# Altium schematic sheet lv_branch_24v.SchDoc(lv_branch_24v)
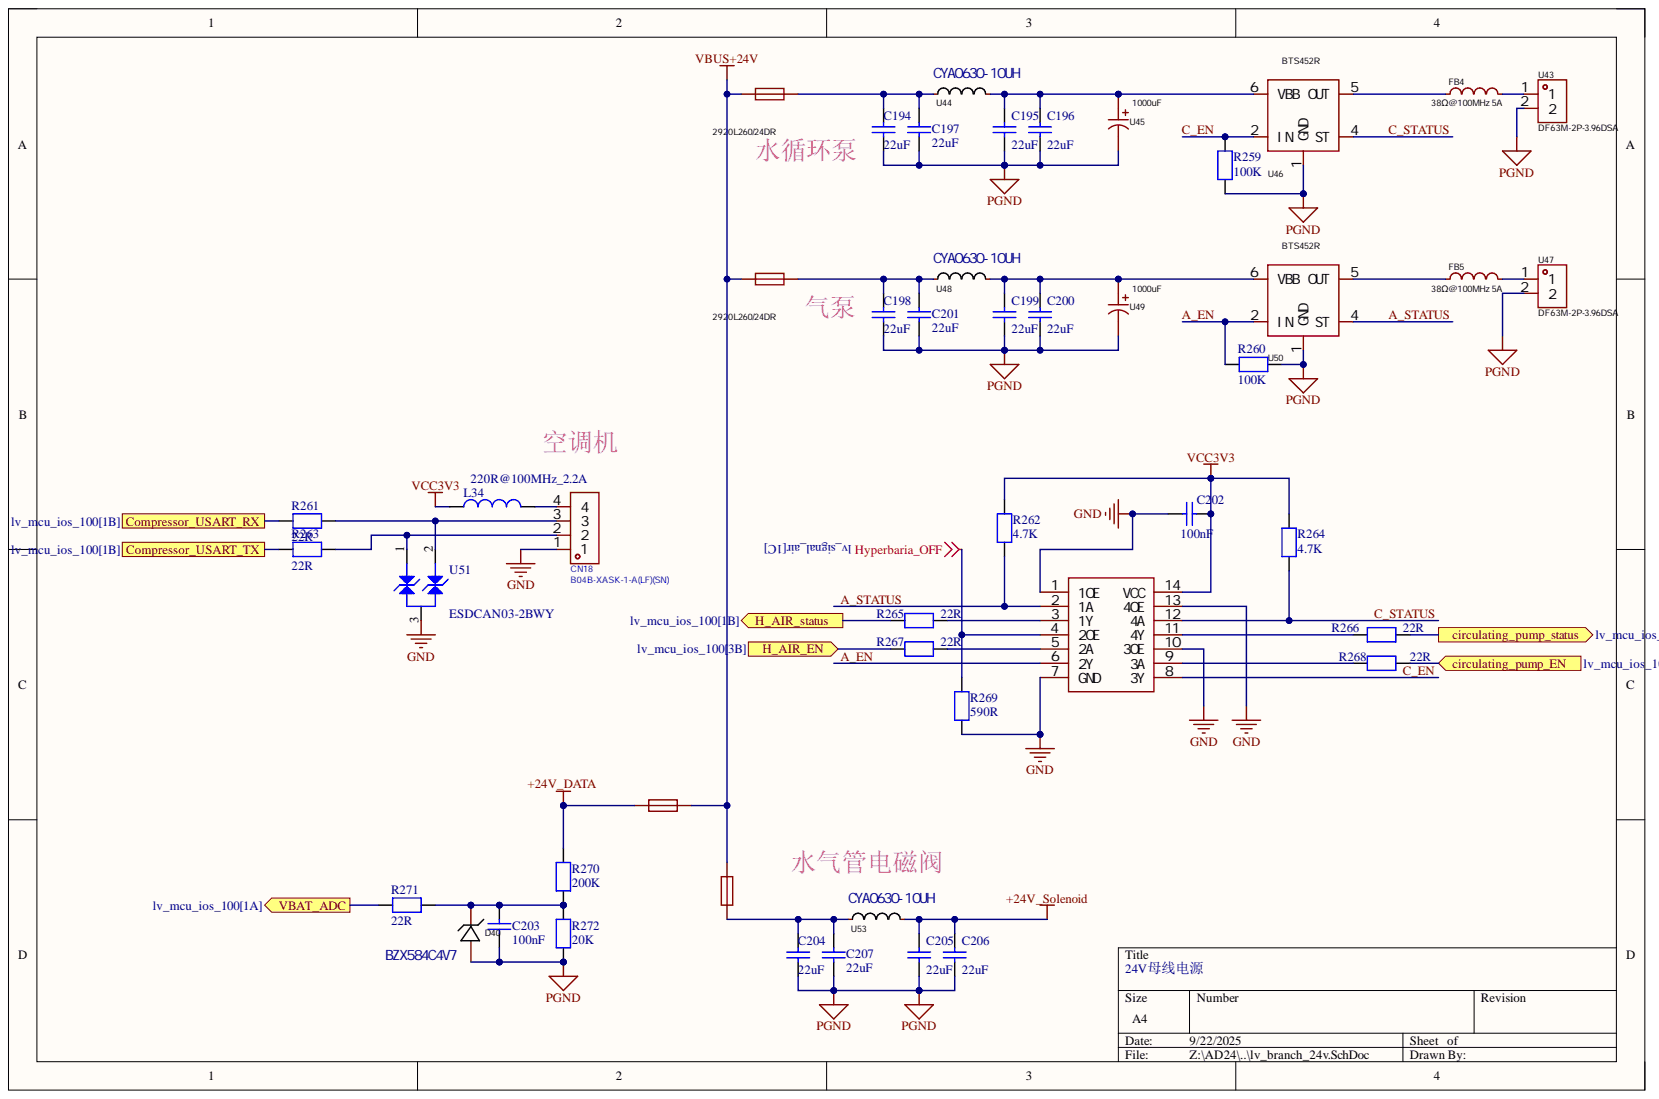
[diagram p0: lv_branch_24v.SchDoc]

## Parts
C194  22uF  [C1206]
C195  22uF  [C1206]
C196  22uF  [C1206]
C197  22uF  [C1206]
C198  22uF  [C1206]
C199  22uF  [C1206]
C200  22uF  [C1206]
C201  22uF  [C1206]
C202  100nF  [C0402]
C203  100nF  [C0402]
C204  22uF  [C1206]
C205  22uF  [C1206]
C206  22uF  [C1206]
C207  22uF  [C1206]
CN18  B04B-XASK-1-A(LF)(SN)  [CONN-TH_B04B-XASK-1-A-LF-SN]
D40  BZX584C4V7  [SOD-523F_L1.2-W0.8-LS1.7-RD]
F3  2920L260/24DR  [F2920]
F4  2920L260/24DR  [F2920]
F5  2920L260/24DR  [F2920]
F6  2920L260/24DR  [F2920]
FB4  LCSC Part Name: 38Ω@100MHz 5A  [L1206]  (hidden comment: PZ3216D380-5R0TF)
FB5  LCSC Part Name: 38Ω@100MHz 5A  [L1206]  (hidden comment: PZ3216D380-5R0TF)
L34  220R@100MHz_2.2A  [L0603]
R259  100K  [R0402]
R260  100K  [R0402]
R261  22R  [R0402]
R262  4.7K  [R0402]
R263  22R  [R0402]
R264  4.7K  [R0402]
R265  22R  [R0402]
R266  22R  [R0402]
R267  22R  [R0402]
R268  22R  [R0402]
R269  590R  [R0402]
R270  200K  [R0603]
R271  22R  [R0402]
R272  20K  [R0603]
U43  DF63M-2P-3.96DSA  [CONN-TH_2P-P3.96_DF63M-2P-3.96DSA]
U44  CYA0630-10UH  [IND-SMD_L7.2-W6.6_GPSR07X0]
U45  1000uF  [CAP-TH_BD10.0-P5.00-D0.6-FD]
U46  BTS452R  [TO-252-5_L6.5-W6.2-P1.14-LS10.0-TL]
U47  DF63M-2P-3.96DSA  [CONN-TH_2P-P3.96_DF63M-2P-3.96DSA]
U48  CYA0630-10UH  [IND-SMD_L7.2-W6.6_GPSR07X0]
U49  1000uF  [CAP-TH_BD10.0-P5.00-D0.6-FD]
U50  BTS452R  [TO-252-5_L6.5-W6.2-P1.14-LS10.0-TL]
U51  ESDCAN03-2BWY  [DIO_SOT323]
U52  74LVC125APW,118  [TSSOP-14_L5.0-W4.4-P0.65-LS6.4-BL]  (value not drawn)
U53  CYA0630-10UH  [IND-SMD_L7.2-W6.6_GPSR07X0]

## Ports
circulating_pump_EN, circulating_pump_status, Compressor_USART_RX, Compressor_USART_TX, H_AIR_EN, H_AIR_status, VBAT_ADC

## Nets
+24V_DATA: F5.2 R270.1
+24V_Solenoid: C205.2 C206.2 U53.2
GND: C202.1 CN18.1 R269.2 U51.3 U52.1(1OE) U52.7(GND) U52.10(3OE) U52.13(4OE)
Hyperbaria_OFF: R269.1 U52.4(2OE)
PGND: C194.1 C195.1 C196.1 C197.1 C198.1 C199.1 C200.1 C201.1 C203.1 C204.1 C205.1 C206.1 C207.1 D40.2 R259.1 R260.2 R272.2 U43.2 U45.2 U46.1(GND) U47.2 U49.2 U50.1(GND)
VBUS+24V: F3.1 F4.1 F5.1 F6.1
VCC3V3: C202.2 L34.2 R262.1 R264.1 U52.14(VCC)
A_EN: R260.1 U50.2(IN) U52.6(2Y)
A_STATUS: R262.2 U50.4(ST) U52.2(1A)
C_EN: R259.2 U46.2(IN) U52.8(3Y)
C_STATUS: R264.2 U46.4(ST) U52.12(4A)
circulating_pump_EN: R268.2 ; port circulating_pump_EN
circulating_pump_status: R266.2 ; port circulating_pump_status
Compressor_USART_RX: R261.1 ; port Compressor_USART_RX
Compressor_USART_TX: R263.1 ; port Compressor_USART_TX
H_AIR_EN: R267.1 ; port H_AIR_EN
H_AIR_status: R265.1 ; port H_AIR_status
VBAT_ADC: R271.1 ; port VBAT_ADC
NetC194_2: C194.2 C197.2 F3.2 U44.1
NetC195_2: C195.2 C196.2 U44.2 U45.1 U46.6(VBB)
NetC198_2: C198.2 C201.2 F4.2 U48.1
NetC199_2: C199.2 C200.2 U48.2 U49.1 U50.6(VBB)
NetC203_2: C203.2 D40.1 R270.2 R271.2 R272.1
NetC204_2: C204.2 C207.2 F6.2 U53.1
NetCN18_2: CN18.2 R263.2 U51.1
NetCN18_3: CN18.3 R261.2 U51.2
NetCN18_4: CN18.4 L34.1
NetFB4_1: FB4.1 U46.5(OUT)
NetFB4_2: FB4.2 U43.1
NetFB5_1: FB5.1 U50.5(OUT)
NetFB5_2: FB5.2 U47.1
NetR265_2: R265.2 U52.3(1Y)
NetR266_1: R266.1 U52.11(4Y)
NetR267_2: R267.2 U52.5(2A)
NetR268_1: R268.1 U52.9(3A)

## Library data of the parts
C194-C201 C204-C207 = Capacitor_2: 35V, X5R, 10%; Manufacturer: TDK; Manufacturer_Part_Number: C3216X5R1V226MTJ00E; Component Kind: Standard; ComponentLink1Description: Datasheet; ComponentLink1URL: http://tunmcdsvn.tun.st.com/Component_Datasheet_Library/Trunk/Capacitors/Yageo_Multilayer_capacitor_X5R_series_100pF_to_100uF; Library Name: HiPin_Library_AD.IntLib
C202 = Capacitor: 50V, 5%, X7R; Manufacturer: muRata; Manufacturer_Part_Number: GRM155R71H104KE14D; Component Kind: Standard; ComponentLink1Description: Datasheet; ComponentLink1URL: http://tunmcdsvn.tun.st.com/Component_Datasheet_Library/Trunk/Capacitors/Yageo_Capacitor_NP0_16V-to-50V; Library Name: HiPin_Library_AD.IntLib
C203 = Capacitor: 50V, 10%, X7R; Internal_Part_Number: MCD-00003; Manufacturer: muRata; Manufacturer_Part_Number: GRM155R71H104KE14D; Component Kind: Standard; ComponentLink1Description: Datasheet; ComponentLink1URL: http://tunmcdsvn.tun.st.com/Component_Datasheet_Library/Trunk/Capacitors/Yageo_Capacitor_NP0_16V-to-50V; Library Name: HiPin_Library_AD.IntLib; Package: C0402; Value: 100nF
CN18 = B04B-XASK-1-A(LF)(SN): 插座XA2.5mm,3A,H8.8mm; Manufacturer: JST; Add into BOM: yes; Flame Retardant Rating: UL94V-0; Number of PINs Per Row: 4; Pins Structure: 1x4P; Pitch: 2.5mm; Plastic Material: 玻纤增强PA66; Rated Voltage (Max): 250V; Reference Series: XA; Supplementary Features: 带柱；打K脚; X-Length of Bottom Edge on Board (Spacing Line): 12.5mm; Y-Width of Bottom Edge on Board: 6.4mm; Z-Height of the Board: 8.8mm; Colour: 白色; Component Kind: Standard; Contact Material: 铜合金; Contact Plating: 锡; Convert to PCB: yes; Current Rating (Max): 3A; Datasheet: https://atta.szlcsc.com/upload/public/pdf/source/20200416/C514162_2DCD7D1CD2019D14145B8FEA1B6236C2.pdf; Device: B04B-XASK-1-A(LF)(SN); JLCPCB Part Class: Extended Part; LCSC Part Name: 1x4P 间距:2.5mm 直插 系列:XA; Library Name: HiPin_Library_AD.IntLib; Manufacturer Part: B04B-XASK-1-A(LF)(SN); Mounting Style: 直插; Number of Pins: 4P; Number of Rows: 1; Operating Temperature Range: -25℃~+85℃; PCB3D: CONN-TH_B04B-XASK-1-A-LF-SN; Row Spacing: -; Supplier: LCSC; Supplier Footprint: 插件,P=2.5mm; Supplier Part: C491709; Symbol: B04B-XASK-1-A(LF)(SN)
D40 = LM5Z3V6T1G: 4.8~5.4V, 200mW; Power Dissipation: 500mW; Component Kind: Standard; Library Name: HiPin_Library_AD.IntLib; Manufacturer Part: BZX584C4V7; Supplier Footprint: SOD-123; Tolerance: -
F3-F6 = 2920L260/24DR: Manufacturer: Littelfuse(美国力特); Add into BOM: yes; Current Rating (Max): 40A; Hold Current: 2.6A; Maximum Time to Trip: 10s; Operating Voltage (Max): 24V; Resistance - Initial (Ri) (Min): 25mΩ; Resistance - Post Trip (R1) (Max): 75mΩ; Trip Current: 5A; Component Kind: Standard; Convert to PCB: yes; Datasheet: https://atta.szlcsc.com/upload/public/pdf/source/20181031/C315905_4DA816BF3BC9EA6CE3926B09DB032E96.pdf; Device: 2920L260/24DR; JLCPCB Part Class: Extended Part; LCSC Part Name: 24V 2.6A 2920自恢复; Library Name: HiPin_Library_AD.IntLib; Manufacturer Part: 2920L260/24DR; Operating Temperature: -40℃~+85℃; Power Dissipation: -; Supplier: LCSC; Supplier Footprint: 2920; Supplier Part: C315904; Symbol: 2920L260/24DR
FB4 FB5 = BLM31PG601SN1L: Manufacturer: Sunlord(顺络); Add into BOM: yes; Circuits: 1; Current Rating: 1.5A; DC Resistance: 80mΩ; Impedance @ Frequency: 600Ω@100MHz; Tolerance: ±25%; Component Kind: Standard; Convert to PCB: yes; Datasheet: https://atta.szlcsc.com/upload/public/pdf/source/20180326/C88997_FE7208E239BA92CF7577D85CF2703D30.pdf; Device: BLM31PG601SN1L; JLCPCB Part Class: Extended Part; Library Name: HiPin_Library_AD.IntLib; Manufacturer Part: PZ3216D380-5R0TF; Supplier: LCSC; Supplier Footprint: 1206; Supplier Part: C16902; Symbol: BLM31PG601SN1L
L34 = MCD-00047: SMD Chip ferrite bead, 220R@100MHz +/-25%,2.2A, 50mohm; Manufacturer: Sunlord; Manufacturer_Part_Number: UPZ1608U221-2R2TF; Database Table Name: MCD_PARTS$; Component Kind: Standard; ComponentLink1Description: Datasheet; ComponentLink1URL: http://tunmcdsvn.tun.st.com/Component_Datasheet_Library/Trunk/Inductors/TaiTech_Bead_FCM1608_series; Internal_Part_Number: MCD-00047; Library Name: MCD_PARTS_database.DbLib
R259-R272 = RES: 1%; Value: 10K; Component Kind: Standard; Library Name: HiPin_Library_AD.IntLib
U43 U47 = DF63M-2P-3.96DSA: Manufacturer: HRS(广濑); Add into BOM: yes; Component Kind: Standard; Convert to PCB: yes; Datasheet: https://atta.szlcsc.com/upload/public/pdf/source/20221205/6AC71532B9B9566170A63CE16B2C46ED.pdf; Device: DF63M-2P-3.96DSA; JLCPCB Part Class: Extended Part; LCSC Part Name: DF63M-2P-3.96DSA; Library Name: HiPin_Library_AD.IntLib; Manufacturer Part: DF63M-2P-3.96DSA; Supplier: LCSC; Supplier Footprint: 插件,P=3.96mm; Supplier Part: C5254350; Symbol: DF63M-2P-3.96DSA
U44 U48 U53 = CYA0630-10UH: Manufacturer: SHOU HAN(首韩); Add into BOM: yes; DC Resistance (DCR): 71mΩ; Name: 10uH; Rated Current: 4A; Tolerance: ±20%; Value: 10uH; Component Kind: Standard; Convert to PCB: yes; Datasheet: https://atta.szlcsc.com/upload/public/pdf/source/20221010/B55E8796F05F85A38044F3826C14EFDD.pdf; Device: CYA0630-10UH; JLCPCB Part Class: Extended Part; LCSC Part Name: 10uH ±20%; Library Name: HiPin_Library_AD.IntLib; Manufacturer Part: CYA0630-10UH; PCB3D: IND-SMD_L7.2-W6.6-H3.0_GPSR07X0; Supplier: LCSC; Supplier Footprint: SMD,6.6x7.2mm; Supplier Part: C5189958; Symbol: CYA0630-10UH
U45 U49 = HG1V108M1016PC: Manufacturer: HONOR(荣誉); Add into BOM: yes; Equivalent Series Resistance(ESR): 28mΩ; L: 16mm; Lifetime @ Temp: 2000hrs@105℃; Rated Voltage: 35V; Ripple Current: 4.2A; Tolerance: ±20%; φD: 10mm; Component Kind: Standard; Convert to PCB: yes; Datasheet: https://atta.szlcsc.com/upload/public/pdf/source/20230323/E6B46A248FBB182638B68380B3289E6D.pdf; Device: HG1V108M1016PC; JLCPCB Part Class: Extended Part; LCSC Part Name: 1000uF ±20% 35V; Lead Spacing: 5mm; Library Name: HiPin_Library_AD.IntLib; Manufacturer Part: HG1V108M1016PC; Operating Temperature: -55℃~+105℃; Supplier: LCSC; Supplier Footprint: 插件,D10xL16mm; Supplier Part: C5438864; Symbol: HG1V108M1016PC; Value: 1000uF
U46 U50 = BTS452R_C126924: Manufacturer: Infineon(英飞凌); Add into BOM: yes; Component Kind: Standard; Convert to PCB: yes; Datasheet: https://atta.szlcsc.com/upload/public/pdf/source/20190419/C386345_DBEE7F1C619255E05785D0D1BACFA6FB.pdf; Device: BTS452R_C126924; JLCPCB Part Class: Extended Part; LCSC Part Name: BTS452R; Library Name: HiPin_Library_AD.IntLib; Manufacturer Part: BTS452R; Supplier: LCSC; Supplier Footprint: TO-252-4; Supplier Part: C126924; Symbol: BTS452R
U51 = MCD-01228: 极性  双向  反向截止电压(Vrwm)  24V  钳位电压  41V  峰值脉冲电流(Ipp)  3.7A@8/20us; Manufacturer: STMICROELECTRONICS; Database Table Name: MCD_PARTS$; Component Kind: Standard; ComponentLink1Description: Datasheet; ComponentLink1URL: http://tunmcdsvn.tun.st.com/Component_Datasheet_Library/Trunk/Diodes/STM_ESD_ESDCAN02-2BWY; Library Name: MCD_PARTS_database.DbLib; Manufacturer_Part_Number: ESDCAN03-2BWY
U52 = 74LVC125APW,118: 4路三态缓冲器; Manufacturer: Nexperia(安世); Add into BOM: yes; Logic Family: 74LVC; Number of Bits per Element: 1; Number of Elements: 4; output type: 三态; Sink Current: 24mA; Supply Voltage: 1.2V~3.6V; Component Kind: Standard; Convert to PCB: yes; Datasheet: https://atta.szlcsc.com/upload/public/pdf/source/20200702/C548083_7401241EC414E68B7416871F88F0FD1D.pdf; Device: 74LVC125APW,118; JLCPCB Part Class: Extended Part; LCSC Part Name: 74LVC125APW,118; Library Name: HiPin_Library_AD.IntLib; Manufacturer Part: 74LVC125APW,118; Operating Temperature: -40℃~+125℃; PCB3D: TSSOP-14_L5.0-W4.4-H1.0-LS6.4-P0.65; Source Current: 24mA; Supplier: LCSC; Supplier Footprint: TSSOP-14; Supplier Part: C6059; Symbol: 74LVC125APW,118
